# Altium schematic sheet 05_ATK-DLMP257_AUDIO.SchDoc
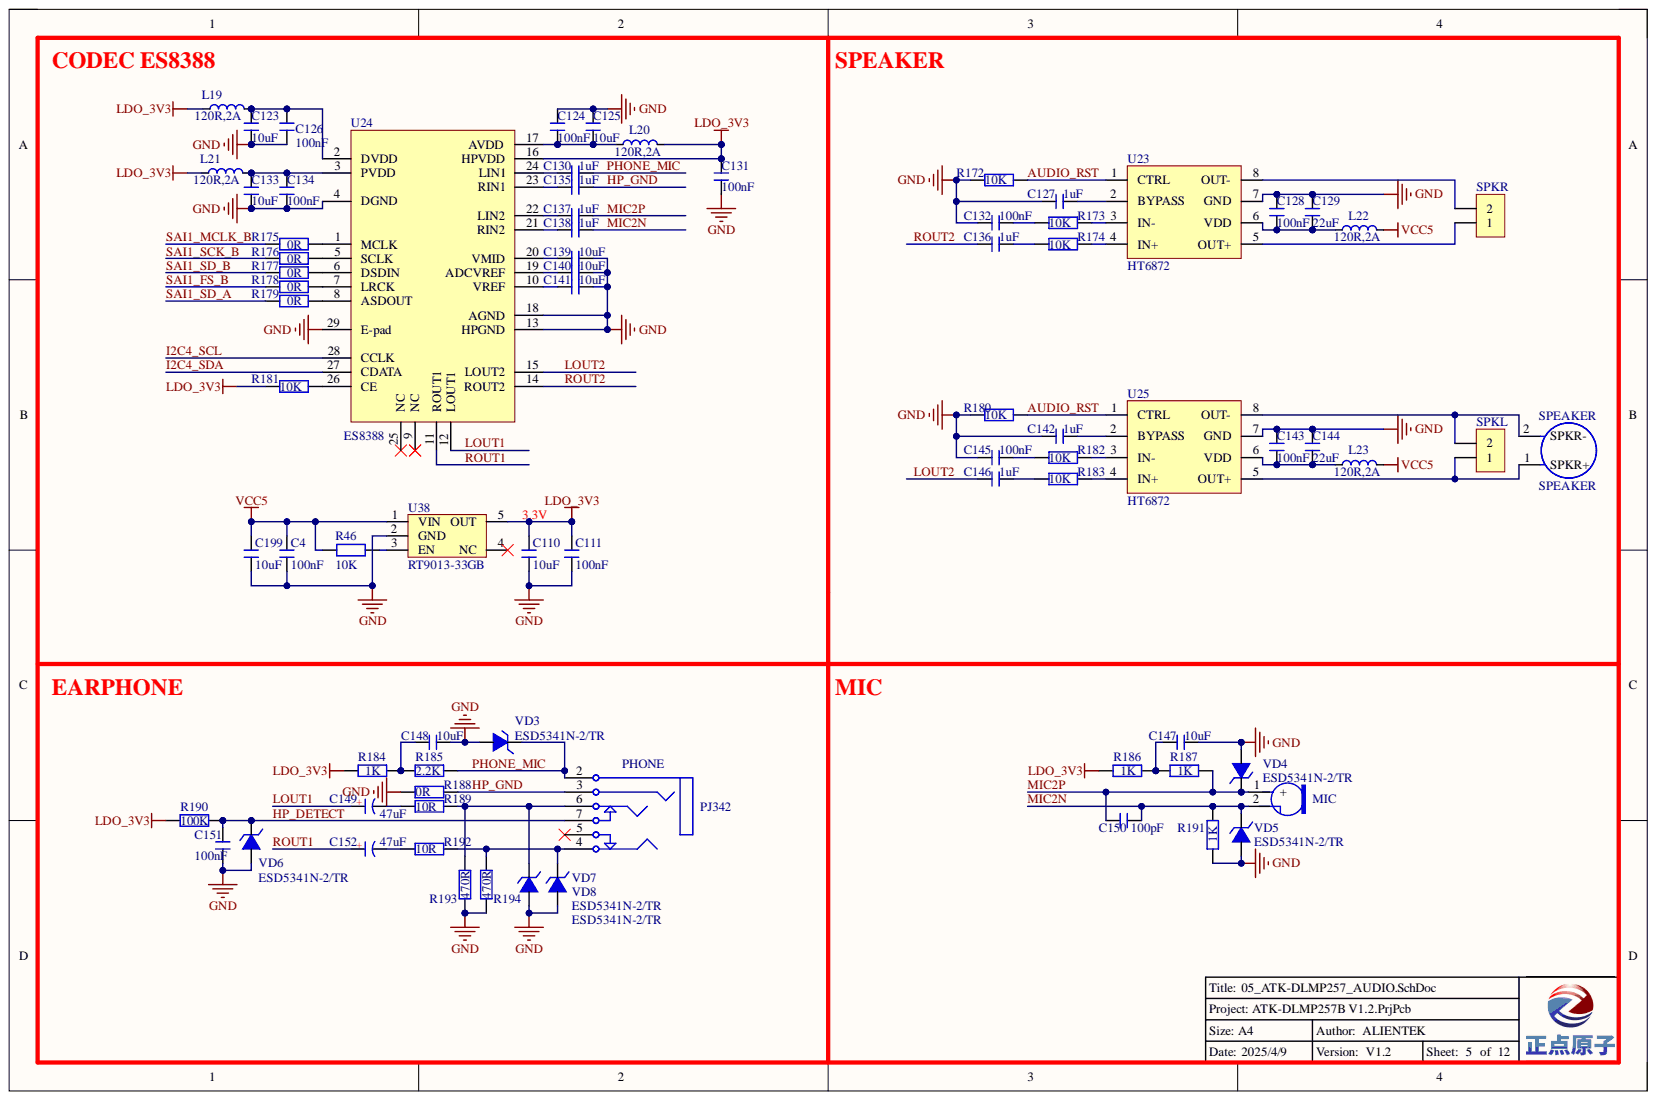
[diagram p0: 05_ATK-DLMP257_AUDIO.SchDoc]

## Parts
C4  100nF  [C 0402]
C110  10uF  [C 0603]
C111  100nF  [C 0402]
C123  10uF  [C 0603]
C124  100nF  [C 0603]
C125  10uF  [C 0603]
C126  100nF  [C 0603]
C127  1uF  [C 0603]
C128  100nF  [C 0603]
C129  22uF  [C 0805]
C130  1uF  [C 0603]
C131  100nF  [C 0603]
C132  100nF  [C 0603]
C133  10uF  [C 0603]
C134  100nF  [C 0603]
C135  1uF  [C 0603]
C136  1uF  [C 0603]
C137  1uF  [C 0603]
C138  1uF  [C 0603]
C139  10uF  [C 0603]
C140  10uF  [C 0603]
C141  10uF  [C 0603]
C142  1uF  [C 0603]
C143  100nF  [C 0603]
C144  22uF  [C 0805]
C145  100nF  [C 0603]
C146  1uF  [C 0603]
C147  10uF  [C 0603]
C148  10uF  [C 0603]
C149  47uF  [CM B(4*5.4)]
C150  100pF  [C 0603]
C151  100nF  [C 0603]
C152  47uF  [CM B(4*5.4)]
C199  10uF  [C 0603]
L19  120R,2A  [L 0603]
L20  120R,2A  [L 0603]
L21  120R,2A  [L 0603]
L22  120R,2A  [L 0603]
L23  120R,2A  [L 0603]
MIC  MIC-6022  [MIC-6022]  (value not drawn)
PHONE  PJ342  [PJ342A]
R46  10K  [R 0603]
R172  10K  [R 0603]
R173  10K  [R 0603]
R174  10K  [R 0603]
R175  0R  [R 0603]
R176  0R  [R 0603]
R177  0R  [R 0603]
R178  0R  [R 0603]
R179  0R  [R 0603]
R180  10K  [R 0603]
R181  10K  [R 0603]
R182  10K  [R 0603]
R183  10K  [R 0603]
R184  1K  [R 0603]
R185  2.2K  [R 0603]
R186  1K  [R 0603]
R187  1K  [R 0603]
R188  0R  [R 0603]
R189  10R  [R 0603]
R190  100K  [R 0603]
R191  1K  [R 0603]
R192  10R  [R 0603]
R193  470R  [R 0603]
R194  470R  [R 0603]
SPEAKER  SPEAKER  [SPEAKER]
SPKL  Header 1X2  [XH2.54-2P H-T]  (value not drawn)
SPKR  Header 1X2  [XH2.54-2P H-T]  (value not drawn)
U23  HT6872  [SOP8_N]
U24  ES8388  [QFN28 4X4_L]
U25  HT6872  [SOP8_N]
U38  RT9013-33GB  [SOT23-5N]
VD3  ESD5341N-2/TR  [ESD0402]
VD4  ESD5341N-2/TR  [ESD0402]
VD5  ESD5341N-2/TR  [ESD0402]
VD6  ESD5341N-2/TR  [ESD0402]
VD7  ESD5341N-2/TR  [ESD0402]
VD8  ESD5341N-2/TR  [ESD0402]

## Nets
GND: C4.1 C110.1 C111.1 C123.1 C124.2 C125.2 C126.1 C127.2 C128.2 C129.1 C131.1 C132.1 C133.1 C134.1 C139.1 C140.1 C141.1 C142.2 C143.2 C144.1 C145.1 C147.2 C148.2 C151.1 C199.1 R172.1 R180.1 R188.1 R191.1 R193.1 R194.1 U23.7(GND) U24.4(DGND) U24.13(HPGND) U24.18(AGND) U24.29(E-pad) U25.7(GND) U38.2(GND) VD3.2 VD4.2 VD5.2 VD6.2 VD7.2 VD8.2
LDO_3V3: C110.2 C111.2 C131.2 L19.1 L20.2 L21.1 R181.1 R184.1 R186.1 R190.1 U24.16(HPVDD) U38.5(OUT)
VCC5: C4.2 C199.2 L22.2 L23.2 R46.1 U38.1(VIN)
AUDIO_RST: R172.2 R180.2 U23.1(CTRL) U25.1(CTRL)
HP_DETECT: C151.2 PHONE.7 R190.2 VD6.1
HP_GND: C135.1 PHONE.3 R188.2
I2C4_SCL: U24.28(CCLK)
I2C4_SDA: U24.27(CDATA)
LOUT1: C149.1 U24.12(LOUT1)
LOUT2: C146.2 U24.15(LOUT2)
MIC2N: C138.1 C150.2 MIC.2 R191.2 VD5.1
MIC2P: C137.1 C150.1 MIC.1 R187.2 VD4.1
PHONE_MIC: C130.1 PHONE.2 R185.2 VD3.1
ROUT1: C152.1 U24.11(ROUT1)
ROUT2: C136.2 U24.14(ROUT2)
SAI1_FS_B: R178.1
SAI1_MCLK_B: R175.1
SAI1_SCK_B: R176.1
SAI1_SD_A: R179.1
SAI1_SD_B: R177.1
NetC123_2: C123.2 C126.2 L19.2 U24.2(DVDD)
NetC124_1: C124.1 C125.1 L20.1 U24.17(AVDD)
NetC127_1: C127.1 U23.2(BYPASS)
NetC128_1: C128.1 C129.2 L22.1 U23.6(VDD)
NetC130_2: C130.2 U24.24(LIN1)
NetC132_2: C132.2 R173.1
NetC133_2: C133.2 C134.2 L21.2 U24.3(PVDD)
NetC135_2: C135.2 U24.23(RIN1)
NetC136_1: C136.1 R174.1
NetC137_2: C137.2 U24.22(LIN2)
NetC138_2: C138.2 U24.21(RIN2)
NetC139_2: C139.2 U24.20(VMID)
NetC140_2: C140.2 U24.19(ADCVREF)
NetC141_2: C141.2 U24.10(VREF)
NetC142_1: C142.1 U25.2(BYPASS)
NetC143_1: C143.1 C144.2 L23.1 U25.6(VDD)
NetC145_2: C145.2 R182.1
NetC146_1: C146.1 R183.1
NetC147_1: C147.1 R186.2 R187.1
NetC148_1: C148.1 R184.2 R185.1
NetC149_2: C149.2 R189.1
NetC152_2: C152.2 R192.1
NetPHONE_4: PHONE.4 R192.2 R194.2 VD8.1
NetPHONE_6: PHONE.6 R189.2 R193.2 VD7.1
NetR46_2: R46.2 U38.3(EN)
NetR173_2: R173.2 U23.3(IN-)
NetR174_2: R174.2 U23.4(IN+)
NetR175_2: R175.2 U24.1(MCLK)
NetR176_2: R176.2 U24.5(SCLK)
NetR177_2: R177.2 U24.6(DSDIN)
NetR178_2: R178.2 U24.7(LRCK)
NetR179_2: R179.2 U24.8(ASDOUT)
NetR181_2: R181.2 U24.26(CE)
NetR182_2: R182.2 U25.3(IN-)
NetR183_2: R183.2 U25.4(IN+)
NetSPEAKER_1: SPEAKER.1 SPKL.1 U25.5(OUT+)
NetSPEAKER_2: SPEAKER.2 SPKL.2 U25.8(OUT-)
NetSPKR_1: SPKR.1 U23.5(OUT+)
NetSPKR_2: SPKR.2 U23.8(OUT-)

Not connected: PHONE 5; U24 9(NC) 25(NC); U38 4(NC)

## Library data of the parts
C4 C110 C111 C124 C126 C128 C131 C132 C134 C143 C145 C150 C151 C199 = C: 无极性贴片电容; 版权归属: 源创客; BOM名称: 无极性贴片电容; Component Kind: Standard; 学习资源: www.ooocke.com
  C4 C110 C111 C199: Library Name: 电阻-电容-电感.SchLib
  C124 C126 C128 C131 C132 C134 C143 C145 C150 C151: Library Name: ATK-DLMP257_V1.0.IntLib
C123 C125 C127 C129 C130 C133 C135-C142 C144 C146-C148 = C: 无极性电容; BOM名称: 无极性贴片电容; 版权归属: 正点原子; Component Kind: Standard; Library Name: 正点原子封装库V1.0.IntLib; 学习资源: www.openedv.com
C149 C152 = C-CM: 贴片电解电容; 版权归属: 正点原子; BOM名称: 贴片电解电容; Component Kind: Standard; Library Name: 正点原子封装库V1.0.IntLib; 学习资源: www.openedv.com
L19-L23 = L: 小功率贴片电感磁珠; 版权归属: 正点原子; BOM名称: 小功率贴片电感; Component Kind: Standard; Library Name: ATK-DLMP257_V1.0.IntLib; 学习资源: www.openedv.com
MIC = MIC-6022: MIC; Parameter: 接口器件; 版权归属: 正点原子; Component Kind: Standard; Library Name: 正点原子封装库V1.0.IntLib; 学习资源: www.openedv.com
PHONE = Phone-PJ342: 3.5耳机插座,带检测和麦克风; BOM名称: 耳机插座; Parameter: 接口器件; 版权归属: 正点原子; Component Kind: Standard; Library Name: ATK-DLMP257_V1.0.IntLib; 学习资源: www.openedv.com
R46 = R: 贴片电阻; BOM名称: 电阻; 版权归属: 正点原子; Component Kind: Standard; Library Name: 电阻-电容-电感.SchLib; 学习资源: www.openedv.com
R172-R194 = R: 电阻; BOM名称: 贴片电阻; 版权归属: 正点原子; Component Kind: Standard; Library Name: 正点原子封装库V1.0.IntLib; Parameter: 电阻; 学习资源: www.openedv.com
SPEAKER = SPEAKER: 喇叭2307; Component Kind: Standard; Library Name: ATK-DLMP257_V1.0.IntLib
SPKL SPKR = Header 2: 2P接插件; Parameter: 接插件; 版权归属: 正点原子; BOM名称: 2P接插件; Component Kind: Standard; Library Name: 正点原子封装库V1.0.IntLib; 学习资源: www.openedv.com
U23 U25 = HT6872: 功放-4.7W单声道D类功放; Component Kind: Standard; Library Name: 正点原子封装库V1.0.IntLib
U24 = ES8388: 音频芯片; Component Kind: Standard; Library Name: ATK-DLIMX93_Library_V1.0.IntLib
U38 = RT9013: LDO 5.5V 500mA; BOM名称: 稳压芯片; Parameter: 芯片; 版权归属: 正点原子; Component Kind: Standard; 学习资源: www.openedv.com; Library Name: Lycreturn.IntLib
VD3-VD8 = ESD5341N: ESD二极管-单向; Component Kind: Standard; Library Name: ATK-DLMP257_V1.0.IntLib
